# Altium schematic sheet BatteryMonitor.SchDoc
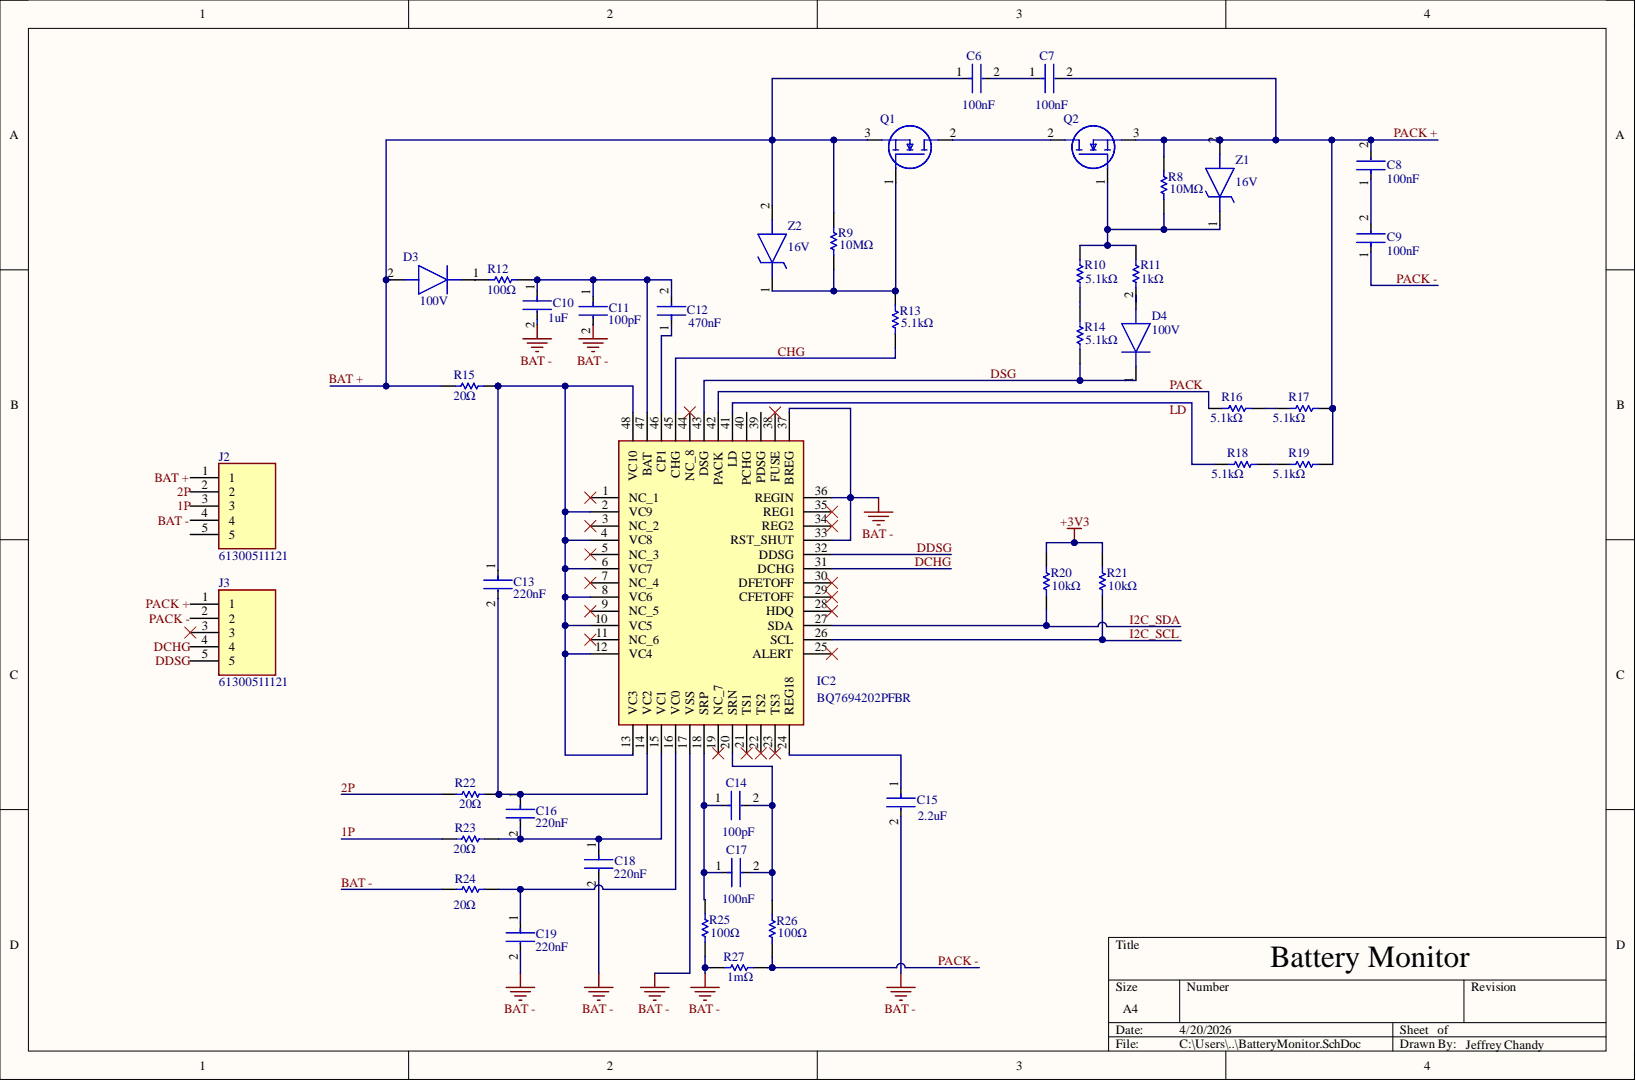
[diagram p0: BatteryMonitor.SchDoc]

## Parts
C6  Capacitance: 100nF  [FP-0603-L_1_6_0_1-W_0_8_0-IPC_A]  (hidden comment: 0.1uiF)
C7  0.1uiF  [FP-0603-L_1_6_0_1-W_0_8_0-IPC_A]  (value not drawn)
C8  Capacitance: 100nF  [FP-0603-L_1_6_0_1-W_0_8_0-IPC_A]  (hidden comment: 0.1uiF)
C9  Capacitance: 100nF  [FP-0603-L_1_6_0_1-W_0_8_0-IPC_A]  (hidden comment: 0.1uiF)
C10  1uF  [FP-1206-L_3_2_0_2-W_1_6_0-IPC_A]
C11  100pF  [FP-0603-L_1_6_0_1-W_0_8_0-IPC_A]
C12  Capacitance: 470nF  [FP-0603-L_1_6_0_1-W_0_8_0-IPC_A]  (hidden comment: 0.47uF)
C13  Capacitance: 220nF  [FP-0603-L_1_6_0_1-W_0_8_0-IPC_A]  (hidden comment: 0.22uF)
C14  100pF  [FP-0603-L_1_6_0_1-W_0_8_0-IPC_A]
C15  2.2uF  [FP-0603-L_1_6_0_1-W_0_8_0-IPC_A]
C16  Capacitance: 220nF  [FP-0603-L_1_6_0_1-W_0_8_0-IPC_A]  (hidden comment: 0.22uF)
C17  Capacitance: 100nF  [FP-0603-L_1_6_0_1-W_0_8_0-IPC_A]  (hidden comment: 0.1uiF)
C18  Capacitance: 220nF  [FP-0603-L_1_6_0_1-W_0_8_0-IPC_A]  (hidden comment: 0.22uF)
C19  Capacitance: 220nF  [FP-0603-L_1_6_0_1-W_0_8_0-IPC_A]  (hidden comment: 0.22uF)
D3  100V  [SOD3716X145N]
D4  100V  [SOD3716X145N]
IC2  BQ7694202PFBR  [QFP50P900X900X120-48N]
J2  61300511121  [HDRV5W64P0X254_1X5_1270X254X854P]
J3  61300511121  [HDRV5W64P0X254_1X5_1270X254X854P]
Q1  DMN6040SK3-13  [DMN6040SK313]  (value not drawn)
Q2  DMN6040SK3-13  [DMN6040SK313]  (value not drawn)
R8  10M  Resistance: 10MΩ  [RESC1608X55X30ML20T10]
R9  10M  Resistance: 10MΩ  [RESC1608X55X30ML20T10]
R10  5.1k  Resistance: 5.1kΩ  [RESC1608X55X30ML20T10]
R11  1k  Resistance: 1kΩ  [RESC1608X55X30ML20T10]
R12  100  Resistance: 100Ω  [RESC1608X55X30ML20T10]
R13  5.1k  Resistance: 5.1kΩ  [RESC1608X55X30ML20T10]
R14  5.1k  Resistance: 5.1kΩ  [RESC1608X55X30ML20T10]
R15  20  Resistance: 20Ω  [RESC1608X55X30ML20T10]
R16  5.1k  Resistance: 5.1kΩ  [RESC1608X55X30ML20T10]
R17  5.1k  Resistance: 5.1kΩ  [RESC1608X55X30ML20T10]
R18  5.1k  Resistance: 5.1kΩ  [RESC1608X55X30ML20T10]
R19  5.1k  Resistance: 5.1kΩ  [RESC1608X55X30ML20T10]
R20  10k  Resistance: 10kΩ  [RESC3216X60X45ML10T20]
R21  10k  Resistance: 10kΩ  [RESC3216X60X45ML10T20]
R22  20  Resistance: 20Ω  [RESC1608X55X30ML20T10]
R23  20  Resistance: 20Ω  [RESC1608X55X30ML20T10]
R24  20  Resistance: 20Ω  [RESC1608X55X30ML20T10]
R25  100  Resistance: 100Ω  [RESC1608X55X30ML20T10]
R26  100  Resistance: 100Ω  [RESC1608X55X30ML20T10]
R27  Resistance: 1mΩ  [RESC3216X180X46ML20T20]  (hidden comment: 0.001)
Z1  16V  [SOD3716X145N]
Z2  16V  [SOD3716X145N]

## Nets
+3V3: R20.2 R21.2
1P: J2.3 R23.2
2P: J2.2 R22.2
BAT +: C6.1 D3.2 J2.1 Q1.3 R9.1 R15.2 Z2.2
BAT -: C10.2 C11.2 C15.2 C18.2 C19.2 IC2.17(VSS) IC2.33(RST_SHUT) IC2.36(REGIN) IC2.37(BREG) J2.4 R24.1 R25.2 R27.1
CHG: IC2.45(CHG) R13.2
DCHG: IC2.31(DCHG) J3.4
DDSG: IC2.32(DDSG) J3.5
DSG: D4.1 IC2.43(DSG) R14.2
I2C_SCL: IC2.26(SCL) R21.1
I2C_SDA: IC2.27(SDA) R20.1
LD: IC2.41(LD) R18.1
PACK: IC2.42(PACK) R16.1
PACK +: C7.2 C8.2 J3.1 Q2.3 R8.1 R17.2 R19.2 Z1.2
PACK -: C9.1 J3.2 R26.2 R27.2
NetC6_2: C6.2 C7.1
NetC8_1: C8.1 C9.2
NetC10_1: C10.1 C11.1 C12.2 IC2.47(BAT) R12.1
NetC12_1: C12.1 IC2.46(CP1)
NetC13_1: C13.1 IC2.2(VC9) IC2.4(VC8) IC2.6(VC7) IC2.8(VC6) IC2.10(VC5) IC2.12(VC4) IC2.13(VC3) IC2.48(VC10) R15.1
NetC13_2: C13.2 C16.1 IC2.14(VC2) R22.1
NetC14_1: C14.1 C17.1 IC2.18(SRP) R25.1
NetC14_2: C14.2 C17.2 IC2.20(SRN) R26.1
NetC15_1: C15.1 IC2.24(REG18)
NetC16_2: C16.2 C18.1 IC2.15(VC1) R23.1
NetC19_1: C19.1 IC2.16(VC0) R24.2
NetD3_1: D3.1 R12.2
NetD4_2: D4.2 R11.2
NetQ1_1: Q1.1 R9.2 R13.1 Z2.1
NetQ1_2: Q1.2 Q2.2
NetQ2_1: Q2.1 R8.2 R10.1 R11.1 Z1.1
NetR10_2: R10.2 R14.1
NetR16_2: R16.2 R17.1
NetR18_2: R18.2 R19.1

Not connected: IC2 1(NC_1) 3(NC_2) 5(NC_3) 7(NC_4) 9(NC_5) 11(NC_6) 19(NC_7) 21(TS1) 22(TS2) 23(TS3) 25(ALERT) 28(HDQ) 29(CFETOFF) 30(DFETOFF) 34(REG2) 35(REG1) 38(FUSE) 39(PDSG) 40(PCHG) 44(NC_8); J2 5; J3 3

## Library data of the parts
C6 C8 C9 C11-C19 = CMP-14477-003254-2: None; Dielectric Material: Ceramic; Tolerance: 10%; Voltage: 50V; Case Code (Imperial): 0603; Case Code (Metric): 1608; Case/Package: 0603; Component Kind: Standard; Construction: Flat; Depth: 0.8mm; Halogen Free: Halogen Free; Height: 0.8mm; Lead Free: Lead Free; Length: 1.6mm; Library Name: Altium Content Vault; Max Operating Temperature: 125°C; Min Operating Temperature: -55°C; Mount: Surface Mount; Number of Pins: 2; Package Quantity: 10; Packaging: Tape and Reel; Radiation Hardening: No; RoHS Compliant: Yes; Series: CFCAP™; Termination: SMD/SMT; Thickness: 0.035inch; Voltage Rating: 50V; Voltage Rating (DC): 50V; Weight: 7.1E-05oz; Width: 0.031inch
  C11 C14: Capacitance: 100pF
  C15: Capacitance: 2.2uF
C7 = CMP-14477-003254-2: None; Capacitance: 100nF; Dielectric Material: Ceramic; Tolerance: 10%; Voltage: 50V; Case Code (Imperial): 0603; Case Code (Metric): 1608; Case/Package: 0603; Component Kind: Standard; Construction: Flat; Depth: 0.8mm; Halogen Free: Halogen Free; Height: 0.8mm; Lead Free: Lead Free; Length: 1.6mm; Library Name: Altium Content Vault; Max Operating Temperature: 125°C; Min Operating Temperature: -55°C; Mount: Surface Mount; Number of Pins: 2; Package Quantity: 10; Packaging: Tape and Reel; Radiation Hardening: No; RoHS Compliant: Yes; Series: CFCAP™; Termination: SMD/SMT; Thickness: 0.035inch; Voltage Rating: 50V; Voltage Rating (DC): 50V; Weight: 7.1E-05oz; Width: 0.031inch
C10 = CMP-04427-000392-1: General Purpose Ceramic Capacitor, 1206, 4.7uF, +80/-20 %, Y5V, +22%/-82%, 16V; Dissipation Factor: 9%; Insulation Resistance: 500MR; Tolerance: 80%; Capacitance: 1uF; Case Code: 1206; Case Code (Imperial): 1206; Case Code (Metric): 3216; Case/Package: 1206; Component Kind: Standard; Construction: Flat; Contact Plating: Tin; Depth: 1.6mm; Dielectric: Y5V; Dielectric Material: Ceramic; Height: 0.33mm; Lead Free: Lead Free; Length: 3.2mm; Library Name: Altium Content Vault; Max Operating Temperature: 85°C; Min Operating Temperature: -30°C; Mount: Surface Mount; Number of Pins: 2; Package Quantity: 1; Packaging: Tape and Reel; Radiation Hardening: No; REACH SVHC: No SVHC; RoHS Compliant: Yes; Series: 1206; Termination: SMD/SMT; Thickness: 0.06inch; Voltage: 16V; Voltage Rating: 16V; Voltage Rating (DC): 16V; Weight: 0.000571oz; Width: 1.6mm
D3 D4 = 1N4148W-7-F: Diode; Manufacturer_Name: Diodes Incorporated; Arrow Part Number: 1N4148W-7-F; Arrow Price/Stock: https://www.arrow.com/en/products/1n4148w-7-f/diodes-incorporated?region=europe; Component Kind: Standard; Datasheet Link: https://www.diodes.com//assets/Datasheets/BAV16W_1N4148W.pdf; Height: 1.45mm; Manufacturer_Part_Number: 1N4148W-7-F; Mouser Part Number: 621-1N4148W-F; Mouser Price/Stock: https://www.mouser.co.uk/ProductDetail/Diodes-Incorporated/1N4148W-7-F?qs=LHX0FizJzg7Ae9ZM8LTAWw%3D%3D
IC2 = BQ7694202PFBR: Integrated Circuit; Manufacturer_Name: Texas Instruments; Arrow Part Number: BQ7694202PFBR; Arrow Price/Stock: null?region=nac; Component Kind: Standard; Datasheet Link: https://www.ti.com/lit/ds/symlink/bq76942.pdf?ts=1642500793238&ref_url=https%253A%252F%252Fwww.ti.com%252Fproduct%252FBQ76942; Height: 1.2mm; Manufacturer_Part_Number: BQ7694202PFBR; Mouser Part Number: 595-BQ7694202PFBR; Mouser Price/Stock: https://www.mouser.co.uk/ProductDetail/Texas-Instruments/BQ7694202PFBR?qs=iLbezkQI%252BshAVl2BMDVCMQ%3D%3D
J2 J3 = 61300511121: Connector; Manufacturer_Name: Wurth Elektronik; Component Kind: Standard; Datasheet Link: http://katalog.we-online.de/em/datasheet/6130xx11121.pdf; Height: 8.54mm; Manufacturer_Part_Number: 61300511121; Mouser Part Number: 710-61300511121; Mouser Price/Stock: https://www.mouser.co.uk/ProductDetail/Wurth-Elektronik/61300511121?qs=PhR8RmCirEZCsCRHq%252BvfRQ%3D%3D
Q1 Q2 = DMN6040SK3-13: MOSFET (N-Channel); Manufacturer_Name: Diodes Incorporated; Arrow Part Number: DMN6040SK3-13; Arrow Price/Stock: https://www.arrow.com/en/products/dmn6040sk3-13/diodes-incorporated; Component Kind: Standard; Datasheet Link: https://www.diodes.com//assets/Datasheets/DMN6040SK3.pdf; Height: 2.52mm; Manufacturer_Part_Number: DMN6040SK3-13; Mouser Part Number: 621-DMN6040SK3-13; Mouser Price/Stock: https://www.mouser.co.uk/ProductDetail/Diodes-Incorporated/DMN6040SK3-13?qs=%252BSlWJf1dfkIR21KUvlLe9A%3D%3D
R8-R19 R23-R26 = CMP-2000-01849-1: 0603 Resistor; Manufacturer: KOA Speer; Part Number: RK73B1JTTD101J; Component Kind: Standard; Library Name: Altium Content Vault
R20 R21 = CMP-2003-02052-1: 1206 Resistor; Manufacturer: Vishay Dale; Part Number: CRCW12062K74FKTA; Component Kind: Standard; Library Name: Altium Content Vault
R22 = CMP-2000-01849-1: Manufacturer: KOA Speer; Part Number: RK73B1JTTD101J; Component Kind: Standard; Library Name: Altium Content Vault
R27 = CMP-2003-04855-1: 1206 Resistor; Manufacturer: Vishay Dale; Part Number: NTHS1206N02N1002KE; Component Kind: Standard; Library Name: Altium Content Vault
Z1 Z2 = MMSZ5246B-7-F: Zener Diode; Manufacturer_Name: Diodes Incorporated; Arrow Part Number: MMSZ5246B-7-F; Arrow Price/Stock: https://www.arrow.com/en/products/mmsz5246b-7-f/diodes-incorporated?region=europe; Component Kind: Standard; Datasheet Link: https://www.diodes.com//assets/Datasheets/ds18010.pdf; Height: 1.45mm; Manufacturer_Part_Number: MMSZ5246B-7-F; Mouser Part Number: 621-MMSZ5246B-F; Mouser Price/Stock: https://www.mouser.co.uk/ProductDetail/Diodes-Incorporated/MMSZ5246B-7-F?qs=YL8BMuhSknSfmeWTDXIh1Q%3D%3D
